# Altium schematic sheet Power.SchDoc
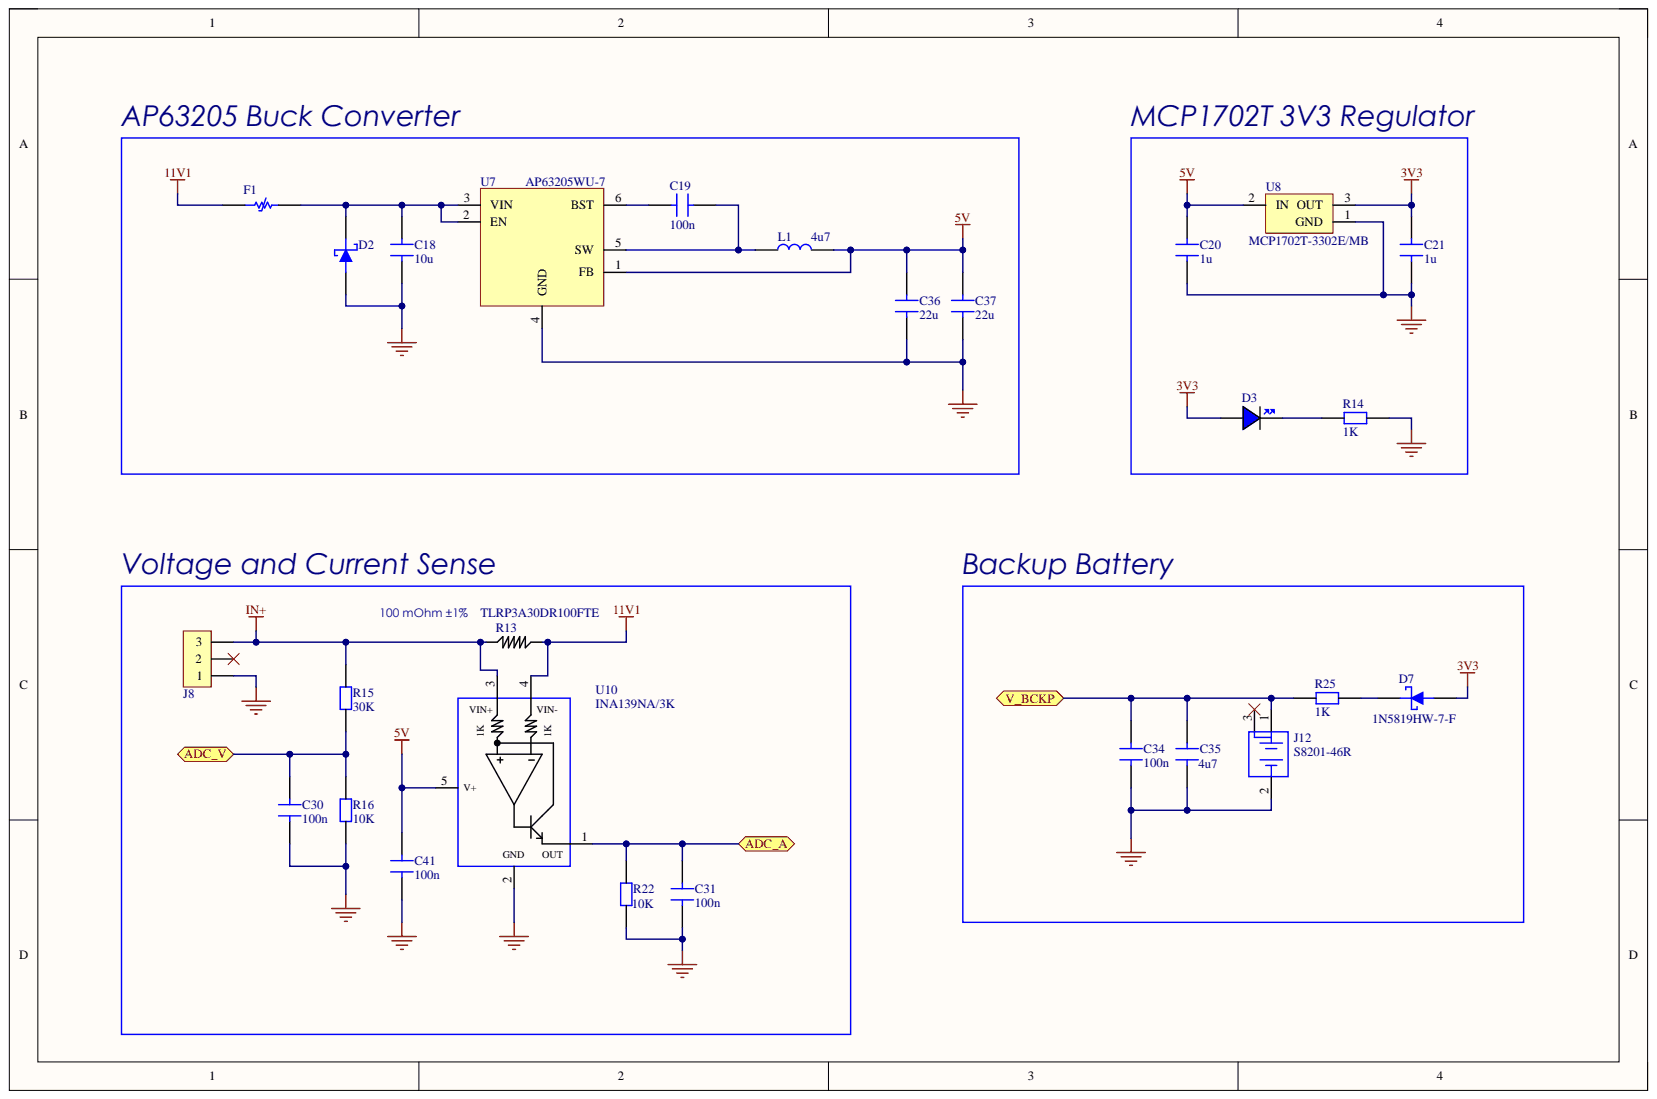
[diagram p0: Power.SchDoc]

## Parts
C18  10u  [CAP 1210]
C19  100n  [CAP 0805]
C20  1u  [CAP 0805]
C21  1u  [CAP 0805]
C30  100n  [CAP 0805]
C31  100n  [CAP 0805]
C34  100n  [CAP 0603]
C35  4u7  [CAP 0805]
C36  22u  [CAP 1210]
C37  22u  [CAP 1210]
C41  100n  [CAP 0805]
D2  SMBJ14CA  [SMBJ12CA-13-F]  (value not drawn)
D3  LED 0805 BLUE  [LED 0805 BLUE]  (value not drawn)
D7  1N5819HW-7-F  [1N5819HW-7-F]
F1  MF-MSMF075/24-2  [0ZCG0075FF2C]  (value not drawn)
J8  JST B3B-XH-A  [JST_B3B-XH-A]  (value not drawn)
J12  S8201-46R  [S8201-46R]
L1  4u7  [IHLP2020CZER4R7M11]
R13  TLRP3A30DR100FTE  [RES 2512]
R14  1K  [RES 0603]
R15  30K  [RES 0805]
R16  10K  [RES 0805]
R22  10K  [RES 0805]
R25  1K  [RES 0603]
U7  AP63205WU-7  [AP63205]
U8  MCP1702T-3302E/MB  [MCP1702T-3302E/MB]
U10  INA139NA/3K  [INA139NA]

## Ports
ADC_A, ADC_V, V_BCKP

## Nets
3V3: C21.2 D3.A D7.C U8.3
5V: C20.2 C36.2 C37.2 C41.2 L1.2 U7.1(FB) U8.2 U10.5(V+)
11V1: F1.1 R13.1 U10.4
GND: C18.1 C20.1 C21.1 C30.1 C31.1 C34.1 C35.1 C36.1 C37.1 C41.1 D2.1 J8.1 J12.2 R14.2 R16.1 R22.1 U7.4(GND) U8.1 U10.2
IN+: J8.3 R13.2 R15.2 U10.3
ADC_A: C31.2 R22.2 U10.1 ; port ADC_A
ADC_V: C30.2 R15.1 R16.2 ; port ADC_V
V_BCKP: C34.2 C35.2 J12.1 R25.1 ; port V_BCKP
NetC18_2: C18.2 D2.2 F1.2 U7.2(EN) U7.3(VIN)
NetC19_1: C19.1 L1.1 U7.5(SW)
NetC19_2: C19.2 U7.6(BST)
NetD3_K: D3.K R14.1
NetD7_A: D7.A R25.2

Not connected: J8 2; J12 3

## Library data of the parts
C18 = CAP 1210 50V: Capacitor SMD 1210 50V; Component Kind: Standard; Library Name: Schlib.SchLib
C19-C21 C30 C31 C41 = CAP 0805 50V: Capacitor SMD 0805 50V; Component Kind: Standard; Library Name: Schlib.SchLib
C34 = CAP 0603 50V: Capacitor SMD 0603 50V; Component Kind: Standard; Library Name: Schlib.SchLib
C35 = CAP 0805 25V: Capacitor SMD 0805 25V; Component Kind: Standard; Library Name: Schlib.SchLib
C36 C37 = CAP 1210 25V: Capacitor SMD 1210 25V; Component Kind: Standard; Library Name: Schlib.SchLib
D2 = SMBJ14CA: TVS DIODE 14VWM 23.2VC DO214AA; Manufacturer: Littelfuse; Breakdown Voltage: 15.6V; Clamping Voltage: 23.2V; Current: 1mA; Diode Type: ZENER; Direction: Bidirectional; Leakage Current: 1uA; Max Breakdown Voltage: 17.2V; Max Power Dissipation: 600W; Max Surge Current: 25.9A; Number of Bidirectional Channels: 1; Operating Supply Voltage: 14V; Power Line Protection: No; Case/Package: DO-214AA; Category: TVS Diodes; Clamping Voltage-Max: 23.2V; Component Kind: Standard; ComponentLink1Description: https://datasheet.ciiva.com/331/littelfuse-tvs-diode-smbj-datasheet-pdf-331530.pdf?src-supplier=Digikey; ComponentLink1URL: https://datasheet.ciiva.com/331/littelfuse-tvs-diode-smbj-datasheet-pdf-331530.pdf?src-supplier=Digikey; ComponentLink2Description: https://datasheet.ciiva.com/331/littelfuse-tvs-diode-smbj-datasheet-pdf-331531.pdf?src-supplier=Digikey; ComponentLink2URL: https://datasheet.ciiva.com/331/littelfuse-tvs-diode-smbj-datasheet-pdf-331531.pdf?src-supplier=Digikey; ComponentLink3Description: https://datasheet.ciiva.com/331/littelfuse-tvs-diode-smbj-datasheet-pdf-331529.pdf?src-supplier=Digikey; ComponentLink3URL: https://datasheet.ciiva.com/331/littelfuse-tvs-diode-smbj-datasheet-pdf-331529.pdf?src-supplier=Digikey; Height: 2.44mm; Lead Free: Lead Free; Length: 5.59mm; Library Name: Schlib.SchLib; Manufacturer Part Number: SMBJ14CA; Max Operating Temperature: 150°C; Min Breakdown Voltage: 15.6V; Min Operating Temperature: -65°C; Mount: Surface Mount; Number of Channels: 1; Number of Elements: 1; Number of Pins: 2; Package Quantity: 3000; Packaging: Tape and Reel; Peak Pulse Current: 25.9A; Peak Pulse Power: 600W; Polarity: Bidirectional; Power Dissipation: 600W; Pricing 1: 1=0,36, 15=0,29, 75=0,245, 300=0,205, 1500=0,163 (USD); Reach SVHC Compliant: No; Reverse Breakdown Voltage: 15.6V; Reverse Standoff Voltage: 14V; RoHS: Yes; RoHS Compliant: Yes; Series: SMBJ; Stock 1: 1300; Supplier 1: Future Electronics; Termination: SMD/SMT; Test Current: 1mA; Voltage: 14V; Voltage Rating (DC): 14V; Weight: 0.00328oz; Width: 3.94mm
D3 = LED 0805 BLUE: LED 0805 GREEN SMD; Component Kind: Standard; Library Name: Schlib.SchLib
D7 = 1N5819HW-7-F: Rectifier Diode, Schottky, 1 Phase, 1 Element, 1A, 40V V(RRM), Silicon; Manufacturer: Diodes; Capacitance: 50pF; Forward Voltage: 450mV; Max Forward Surge Current (Ifsm): 25A; Max Power Dissipation: 450mW; Max Reverse Current: 50uA; Polarity: Standard; Average Rectified Current: 1A; Case/Package: SOD-123-2; Category: Rectifier Diodes; Component Kind: Standard; ComponentLink1Description: https://datasheet.ciiva.com/27092/1538419-27092484.pdf?src-supplier=Jameco; ComponentLink1URL: https://datasheet.ciiva.com/27092/1538419-27092484.pdf?src-supplier=Jameco; ComponentLink2Description: https://datasheet.ciiva.com/16303/ds30217-16303296.pdf?src-supplier=Future+Electronics; ComponentLink2URL: https://datasheet.ciiva.com/16303/ds30217-16303296.pdf?src-supplier=Future+Electronics; ComponentLink3Description: https://datasheet.ciiva.com/1177/ds30217-1177089.pdf?src-supplier=Element14; ComponentLink3URL: https://datasheet.ciiva.com/1177/ds30217-1177089.pdf?src-supplier=Element14; ComponentLink4Description: https://datasheet.ciiva.com/8303/ds30217-8303132.pdf?src-supplier=Avnet; ComponentLink4URL: https://datasheet.ciiva.com/8303/ds30217-8303132.pdf?src-supplier=Avnet; ComponentLink5Description: https://datasheet.ciiva.com/912/ds30217-912802.pdf?src-supplier=Digikey; ComponentLink5URL: https://datasheet.ciiva.com/912/ds30217-912802.pdf?src-supplier=Digikey; ComponentLink6Description: https://datasheet.ciiva.com/912/ds30217-912803.pdf?src-supplier=Digikey; ComponentLink6URL: https://datasheet.ciiva.com/912/ds30217-912803.pdf?src-supplier=Digikey; ComponentLink7Description: https://datasheet.ciiva.com/912/ds30217-912801.pdf?src-supplier=Digikey; ComponentLink7URL: https://datasheet.ciiva.com/912/ds30217-912801.pdf?src-supplier=Digikey; Current Rating: 1A; Forward Current: 1A; Height: 1.25mm; Lead Free: Lead Free; Length: 2.85mm; Library Name: Schlib.SchLib; Manufacturer Part Number: 1N5819HW-7-F; Max Operating Temperature: 125°C; Max Repetitive Reverse Voltage (Vrrm): 40V; Max Reverse Voltage (DC): 40V; Min Operating Temperature: -65°C; Number of Pins: 2; Output Current: 1A; Package Quantity: 3000; Packaging: Tape and Reel; Peak Non-Repetitive Surge Current: 25A; Pricing 1: 3000=0,0409, 9000=0,0394, 15000=0,0388, 60000=0,037, 90000=0,0358 (USD); Radiation Hardening: No; Reach SVHC Compliant: No; Reverse Voltage: 40V; RoHS: Yes; RoHS Compliant: Yes; Series: -; Stock 1: 5079000; Supplier 1: Future Electronics; Termination: SMD/SMT; Voltage Rating (DC): 40V; Weight: 0.000353oz; Width: 1.7mm
F1 = MF-MSMF075/24-2: PTC Resettable Fuse 0.75A(hold) 1.5A(trip) 24V 40A 0.8W 0.2s 0.11Ohm SMD Solder Pad 1812 T/R Automotive; Manufacturer: Bourns; Category: Fuses; Component Kind: Standard; ComponentLink1Description: https://datasheet.ciiva.com/27091/1164226-27091560.pdf?src-supplier=Jameco; ComponentLink1URL: https://datasheet.ciiva.com/27091/1164226-27091560.pdf?src-supplier=Jameco; ComponentLink2Description: https://datasheet.ciiva.com/326/mfmsmf-326789.pdf?src-supplier=Digikey; ComponentLink2URL: https://datasheet.ciiva.com/326/mfmsmf-326789.pdf?src-supplier=Digikey; ComponentLink3Description: https://datasheet.ciiva.com/326/mfmsmf-326790.pdf?src-supplier=Digikey; ComponentLink3URL: https://datasheet.ciiva.com/326/mfmsmf-326790.pdf?src-supplier=Digikey; ComponentLink4Description: https://datasheet.ciiva.com/326/mfmsmf-326791.pdf?src-supplier=Digikey; ComponentLink4URL: https://datasheet.ciiva.com/326/mfmsmf-326791.pdf?src-supplier=Digikey; ComponentLink5Description: https://datasheet.ciiva.com/3019/mfmsmf-3019624.pdf?src-supplier=Avnet; ComponentLink5URL: https://datasheet.ciiva.com/3019/mfmsmf-3019624.pdf?src-supplier=Avnet; ComponentLink6Description: https://datasheet.ciiva.com/3019/mfmsmf-3019625.pdf?src-supplier=Avnet; ComponentLink6URL: https://datasheet.ciiva.com/3019/mfmsmf-3019625.pdf?src-supplier=Avnet; ComponentLink7Description: https://datasheet.ciiva.com/30254/575mfmsmf-30254266.pdf?src-supplier=Onlinecomponents.com; ComponentLink7URL: https://datasheet.ciiva.com/30254/575mfmsmf-30254266.pdf?src-supplier=Onlinecomponents.com; ComponentLink8Description: https://datasheet.ciiva.com/16205/mfmsmf-16205996.pdf?src-supplier=Onlinecomponents.com; ComponentLink8URL: https://datasheet.ciiva.com/16205/mfmsmf-16205996.pdf?src-supplier=Onlinecomponents.com; ComponentLink9Description: https://datasheet.ciiva.com/14539/70153434-14539177.pdf?src-supplier=Allied+Electronics; ComponentLink9URL: https://datasheet.ciiva.com/14539/70153434-14539177.pdf?src-supplier=Allied+Electronics; ComponentLink10Description: https://datasheet.ciiva.com/1228/1786007-1228156.pdf?src-supplier=Newark; ComponentLink10URL: https://datasheet.ciiva.com/1228/1786007-1228156.pdf?src-supplier=Newark; ComponentLink11Description: https://datasheet.ciiva.com/1274/1786007-1274502.pdf?src-supplier=Element14; ComponentLink11URL: https://datasheet.ciiva.com/1274/1786007-1274502.pdf?src-supplier=Element14; ComponentLink12Description: https://datasheet.ciiva.com/1274/1786007-1274503.pdf?src-supplier=Element14; ComponentLink12URL: https://datasheet.ciiva.com/1274/1786007-1274503.pdf?src-supplier=Element14; Lead Free: 5697LeadFree; Library Name: Schlib.SchLib; Manufacturer Part Number: MF-MSMF075/24-2; Pricing 1: 4000=0,2686 (USD); RoHS: Yes; Stock 1: 88000; Supplier 1: Arrow Electronics
J8 = JST B3B-XH-A: Connector Header, XH Series, 3 Position, 2.50mm, Through Hole, Press-Fit, Solder, Natural; Manufacturer Part Number: B3B-XH-A(LF)(SN); Category: Headers and Wire Housings; Component Kind: Standard; ComponentLink1Description: https://datasheet.ciiva.com/26894/getdatasheetpartid-640434-26894454.pdf?src-supplier=Verical; ComponentLink1URL: https://datasheet.ciiva.com/26894/getdatasheetpartid-640434-26894454.pdf?src-supplier=Verical; ComponentLink2Description: https://datasheet.ciiva.com/26894/getdatasheetpartid-440399-26894778.pdf?src-supplier=Verical; ComponentLink2URL: https://datasheet.ciiva.com/26894/getdatasheetpartid-440399-26894778.pdf?src-supplier=Verical; ComponentLink3Description: https://datasheet.ciiva.com/11746/0900766b8082653f-11746520.pdf?src-supplier=Rs; ComponentLink3URL: https://datasheet.ciiva.com/11746/0900766b8082653f-11746520.pdf?src-supplier=Rs; Library Name: Schlib.SchLib; Manufacturer: JST; Pricing 1: 1=39,94, 10=25,1, 50=21,68, 100=18,25, 500=17,57, 1000=17,12, 2000=16,44, 4000=15,79 (JPY); RoHS: Yes; Stock 1: 2101; Supplier 1: CoreStaff
J12 = S8201-46R: Battery Holder, Coin Cell, 1225, Smd Rohs Compliant: Yes |Harwin S8201-46R; Manufacturer: Harwin; Number of Contacts: 2NUMBER; Category: Connectors; Component Kind: Standard; ComponentLink1Description: https://datasheet.ciiva.com/4/s8201r-4048.pdf?src-supplier=Digikey; ComponentLink1URL: https://datasheet.ciiva.com/4/s8201r-4048.pdf?src-supplier=Digikey; ComponentLink2Description: https://datasheet.ciiva.com/4642/c0430120-20coin20cell20battery20retainers-55143-4642583.pdf?src-supplier=Mouser; ComponentLink2URL: https://datasheet.ciiva.com/4642/c0430120-20coin20cell20battery20retainers-55143-4642583.pdf?src-supplier=Mouser; ComponentLink3Description: https://datasheet.ciiva.com/1391/1458706-1391064.pdf?src-supplier=Element14; ComponentLink3URL: https://datasheet.ciiva.com/1391/1458706-1391064.pdf?src-supplier=Element14; ComponentLink4Description: https://datasheet.ciiva.com/4/s8201r-4049.pdf?src-supplier=Digikey; ComponentLink4URL: https://datasheet.ciiva.com/4/s8201r-4049.pdf?src-supplier=Digikey; Contact Material: 153,154ConductorMaterial; Height: 3.25mm; Library Name: Schlib.SchLib; Manufacturer Part Number: S8201-46R; Pricing 1: 1=0,926, 25=0,765, 75=0,589, 200=0,555, 500=0,48 (EUR); RoHS: Yes; Stock 1: 4494; Supplier 1: Farnell
L1 = IHLP2020CZER4R7M11: Inductor Power Shielded Wirewound 4.7uH 20% 100KHz Powdered Iron 4.5A 60mOhm DCR 2020 T/R; Manufacturer: Vishay; Category: Fixed Inductors; Component Kind: Standard; ComponentLink1Description: https://datasheet.ciiva.com/14803/lp20cz11-14803040.pdf?src-supplier=Arrow+Electronics; ComponentLink1URL: https://datasheet.ciiva.com/14803/lp20cz11-14803040.pdf?src-supplier=Arrow+Electronics; ComponentLink2Description: https://datasheet.ciiva.com/11798/0900766b8102c930-11798346.pdf?src-supplier=Rs; ComponentLink2URL: https://datasheet.ciiva.com/11798/0900766b8102c930-11798346.pdf?src-supplier=Rs; ComponentLink3Description: https://datasheet.ciiva.com/22694/480942-22694470.pdf?src-supplier=Element14; ComponentLink3URL: https://datasheet.ciiva.com/22694/480942-22694470.pdf?src-supplier=Element14; ComponentLink4Description: https://datasheet.ciiva.com/8017/480942-8017228.pdf?src-supplier=Element14; ComponentLink4URL: https://datasheet.ciiva.com/8017/480942-8017228.pdf?src-supplier=Element14; ComponentLink5Description: https://datasheet.ciiva.com/8494/lp20cz11-8494950.pdf?src-supplier=Avnet; ComponentLink5URL: https://datasheet.ciiva.com/8494/lp20cz11-8494950.pdf?src-supplier=Avnet; ComponentLink6Description: https://datasheet.ciiva.com/17112/70205433-17112327.pdf?src-supplier=Allied+Electronics; ComponentLink6URL: https://datasheet.ciiva.com/17112/70205433-17112327.pdf?src-supplier=Allied+Electronics; ComponentLink7Description: https://datasheet.ciiva.com/6688/lp20cz11-6688326.pdf?src-supplier=Digikey; ComponentLink7URL: https://datasheet.ciiva.com/6688/lp20cz11-6688326.pdf?src-supplier=Digikey; ComponentLink8Description: https://datasheet.ciiva.com/6688/lp20cz11-6688327.pdf?src-supplier=Digikey; ComponentLink8URL: https://datasheet.ciiva.com/6688/lp20cz11-6688327.pdf?src-supplier=Digikey; ComponentLink9Description: https://datasheet.ciiva.com/6688/lp20cz11-6688329.pdf?src-supplier=Digikey; ComponentLink9URL: https://datasheet.ciiva.com/6688/lp20cz11-6688329.pdf?src-supplier=Digikey; Library Name: Schlib.SchLib; Manufacturer Part Number: IHLP2020CZER4R7M11; Pricing 1: 1=1,19, 10=1,02, 50=1,02, 100=0,828, 1000=0,828, 10000=0,473 (USD); RoHS: No; Stock 1: 10031; Supplier 1: Mouser
R13 = TLRP3A30DR100FTE: Res, 0R1, 1%, 3W, Metal Strip, SMD Rohs Compliant: Yes; Manufacturer: TE Connectivity; Category: Chip SMD Resistors; Component Kind: Standard; Library Name: Schlib.SchLib; Manufacturer Part Number: TLRP3A30DR100FTE; Pricing 1: 10=0,393, 100=0,273, 500=0,221, 1000=0,179, 2000=0,177, 4000=0,166, 20000=0,163, 40000=0,159 (EUR); RoHS: No; Stock 1: 1580; Supplier 1: Farnell
R14 R25 = RES 0603: Resistor SMD 0603; Component Kind: Standard; Library Name: Schlib.SchLib
R15 R16 R22 = RES 0805: Resistor SMD 0805; Component Kind: Standard; Library Name: Schlib.SchLib
U7 = AP63205WU-7: AP63205 Series 32 V 2 A 1.1 MHz Synchronous Buck Converter -TSOT-26; Manufacturer: Diodes; Max Duty Cycle: 100%; Max Supply Voltage: 32V; Min Supply Voltage: 3.8V; Nominal Supply Current: 22uA; Number of Outputs: 1NUMBER; Output Current: 2A; Output Voltage: 5V; Switching Frequency: 1.1MHz; Category: Voltage Regulators - Switching; Component Kind: Standard; ComponentLink1Description: https://www.diodes.com/assets/Datasheets/AP63200-AP63201-AP63203-AP63205.pdf?src-supplier=Digikey; ComponentLink1URL: https://www.diodes.com/assets/Datasheets/AP63200-AP63201-AP63203-AP63205.pdf?src-supplier=Digikey; Height: 1mm; Library Name: Schlib.SchLib; Manufacturer Part Number: AP63205WU-7; Max Operating Temperature: 85°C; Min Operating Temperature: -40°C; Pricing 1: 3000=0,33, 6000=0,32 (USD); RoHS: Yes; Stock 1: 45000; Supplier 1: Future Electronics
U8 = MCP1702T-3302E/MB: LDO Regulator Pos 3.3V 0.25A Automotive 4-Pin(3+Tab) SOT-89 T/R; Manufacturer: Microchip; Accuracy: 2%; Dropout Voltage: 525mV; Max Output Current: 250mA; Max Supply Voltage: 13.2V; Min Supply Voltage: 2.7V; Number of Outputs: 1; Output Type: Fixed; Polarity: Positive; Quiescent Current: 5uA; Input Bias Current: 2uA; Case/Package: SOT-89; Category: Voltage Regulators - Linear; Component Kind: Standard; Height: 1.6mm; Lead Free: Lead Free; Length: 4.6mm; Library Name: Schlib.SchLib; Manufacturer Part Number: MCP1702T-3302E/MB; Max Operating Temperature: 125°C; Max Output Voltage: 3.3V; Max Input Voltage: 13.2V; Min Operating Temperature: -40°C; Min Output Voltage: 3.3V; Min Input Voltage: 2.7V; Mount: Surface Mount; Nominal Output Voltage: 3.3V; Number of Pins: 3; Number of Regulators: 1; Output Current: 250mA; Output Voltage: 3.3V; Output Voltage Accuracy: 2%; Package Quantity: 1000; Packaging: Tape and Reel; Pricing 1: 1000=0,4964 (USD); Reach SVHC Compliant: No; RoHS: Yes; RoHS Compliant: Yes; Stock 1: 26000; Supplier 1: Arrow Electronics; Supply Current: 5uA; Termination: SMD/SMT; Width: 2.29mm
U10 = INA139NA/3K: 2.7 to 36V, 440kHz Variable gain current sense amplifier 5-SOT-23 -40 to 125; Manufacturer: Texas Instruments; Category: Amplifiers - Op Amps, Buffer, Instrumentation; Component Kind: Standard; ComponentLink1Description: https://datasheet.ciiva.com/15128/getdatasheetpartid-248784-15128606.pdf?src-supplier=Verical; ComponentLink1URL: https://datasheet.ciiva.com/15128/getdatasheetpartid-248784-15128606.pdf?src-supplier=Verical; ComponentLink2Description: https://datasheet.ciiva.com/1939/ina139-1939992.pdf?src-supplier=Digikey; ComponentLink2URL: https://datasheet.ciiva.com/1939/ina139-1939992.pdf?src-supplier=Digikey; ComponentLink3Description: https://datasheet.ciiva.com/1939/ina139-1939993.pdf?src-supplier=Digikey; ComponentLink3URL: https://datasheet.ciiva.com/1939/ina139-1939993.pdf?src-supplier=Digikey; ComponentLink4Description: https://datasheet.ciiva.com/1939/ina139-1939994.pdf?src-supplier=Digikey; ComponentLink4URL: https://datasheet.ciiva.com/1939/ina139-1939994.pdf?src-supplier=Digikey; ComponentLink5Description: https://datasheet.ciiva.com/2976/ina169-2976115.pdf?src-supplier=Avnet; ComponentLink5URL: https://datasheet.ciiva.com/2976/ina169-2976115.pdf?src-supplier=Avnet; Library Name: Schlib.SchLib; Manufacturer Part Number: INA139NA/3K; Pricing 1: 1=1,5, 10=1,27, 50=1,27, 100=1,02, 1000=0,737, 10000=0,736 (USD); RoHS: Yes; Stock 1: 20541; Supplier 1: Mouser
